# Altium schematic sheet Controller.SchDoc(Controller)
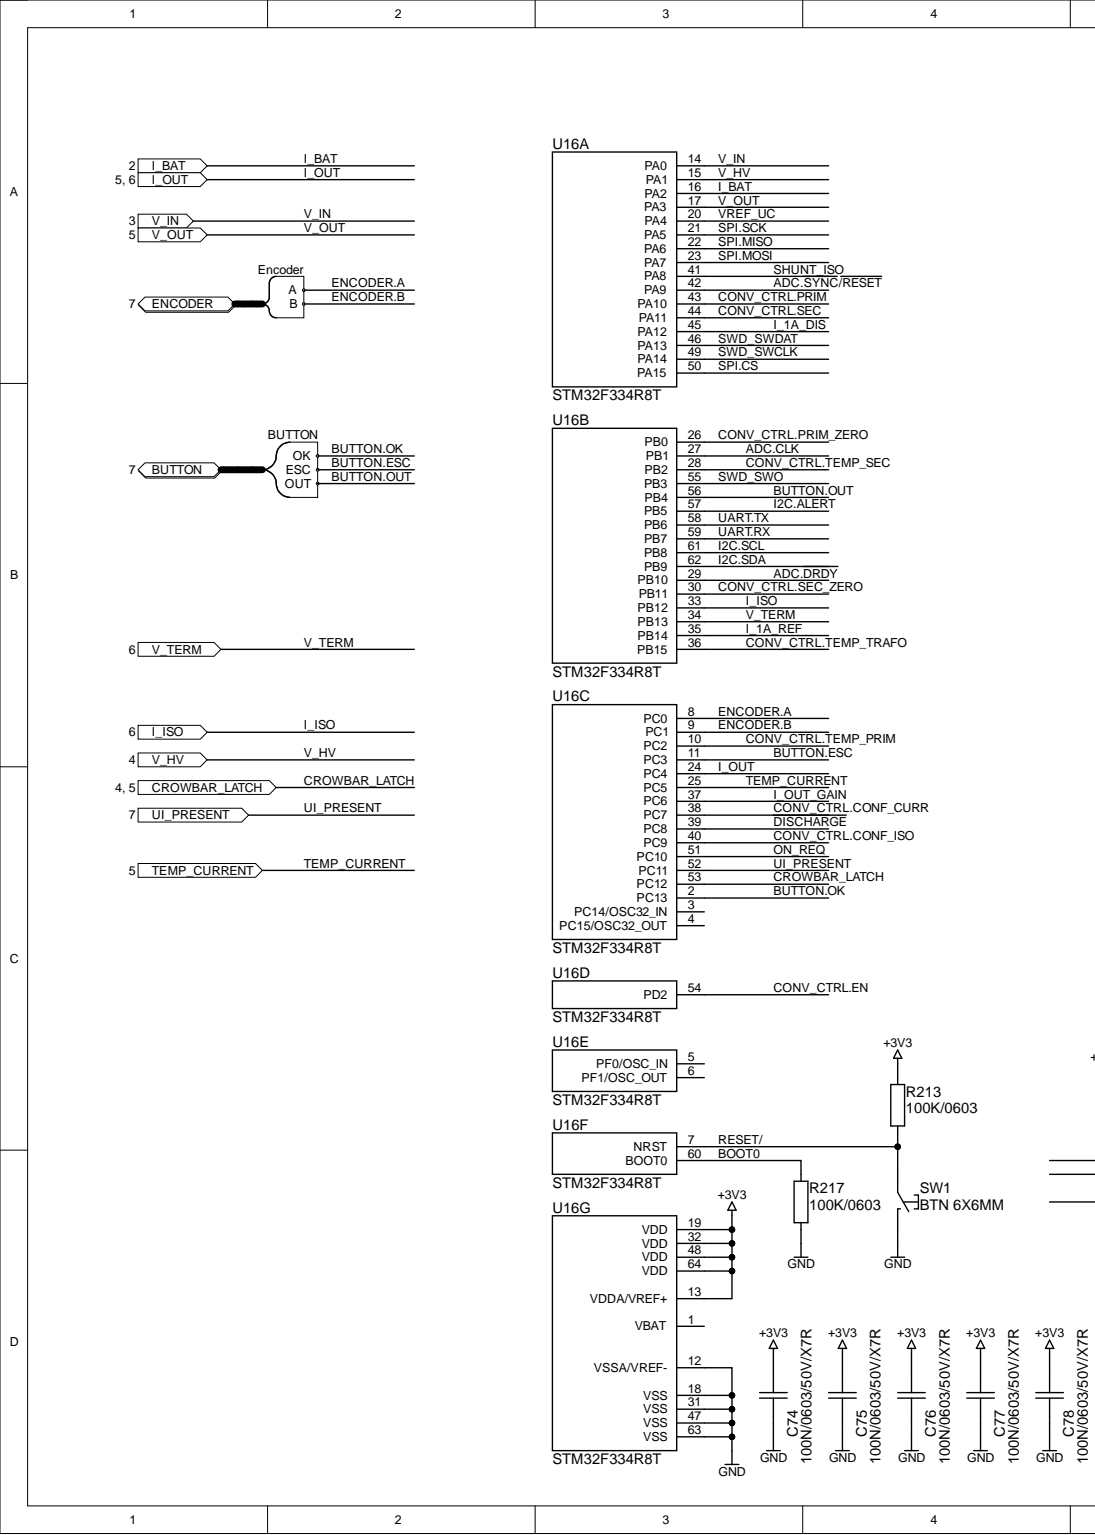
[diagram p0: Controller.SchDoc, left]
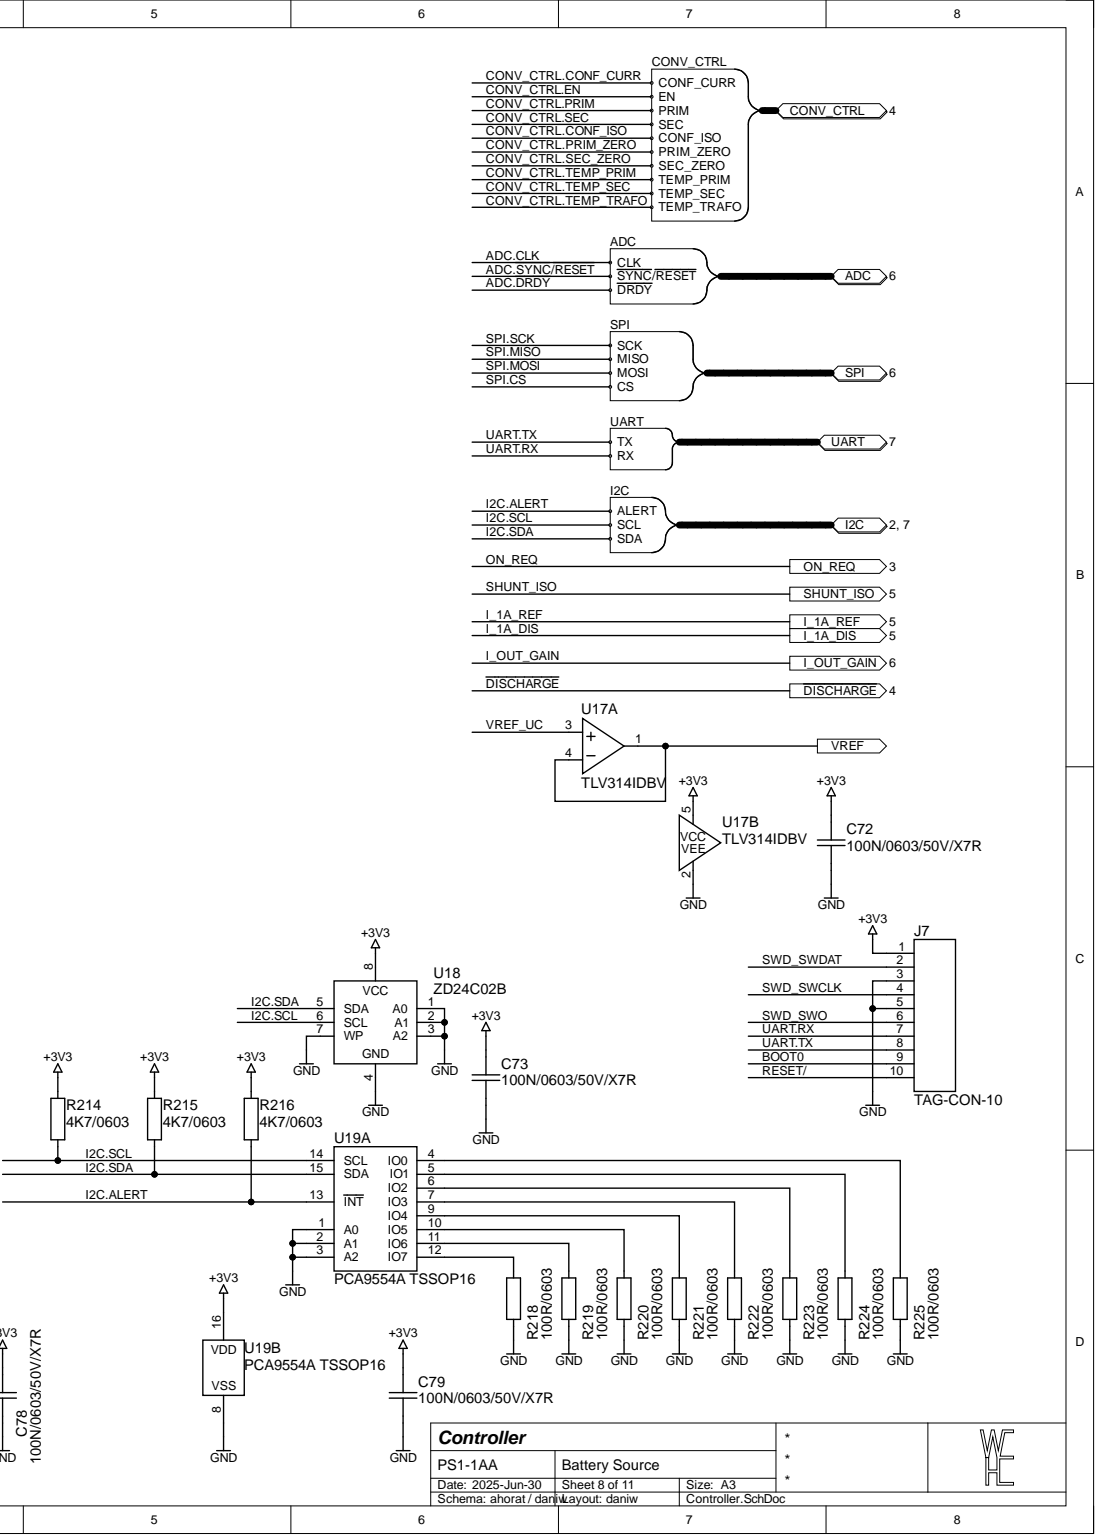
[diagram p1: Controller.SchDoc, right]

## Parts
C72  100N/0603/50V/X7R  [CAP0603_X7R]
C73  100N/0603/50V/X7R  [CAP0603_X7R]
C74  100N/0603/50V/X7R  [CAP0603_X7R]
C75  100N/0603/50V/X7R  [CAP0603_X7R]
C76  100N/0603/50V/X7R  [CAP0603_X7R]
C77  100N/0603/50V/X7R  [CAP0603_X7R]
C78  100N/0603/50V/X7R  [CAP0603_X7R]
C79  100N/0603/50V/X7R  [CAP0603_X7R]
J7  TAG-CON-10  [TC2050]
R213  100K/0603  [R0603-0.40-WW]
R214  4K7/0603  [R0603-0.40-WW]
R215  4K7/0603  [R0603-0.40-WW]
R216  4K7/0603  [R0603-0.40-WW]
R217  100K/0603  [R0603-0.40-WW]
R218  100R/0603  [R0603-0.40-WW]
R219  100R/0603  [R0603-0.40-WW]
R220  100R/0603  [R0603-0.40-WW]
R221  100R/0603  [R0603-0.40-WW]
R222  100R/0603  [R0603-0.40-WW]
R223  100R/0603  [R0603-0.40-WW]
R224  100R/0603  [R0603-0.40-WW]
R225  100R/0603  [R0603-0.40-WW]
SW1  BTN 6X6MM  [BTN-6X6MM-4.3MM-YELLOW]
U16  STM32F334R8T  [QFP050P1200X1200X160-64]  (parts A,B,C,D,E,F,G)
U17  TLV314IDBV  [SOT2395P280X125-5-NW]  (parts A,B)
U18  ZD24C02B  [SOP127P600X155-8-SW]
U19  PCA9554A TSSOP16  [SOP065P640X110-16NW]  (parts A,B)

## Ports
ADC, ADC.CLK, ADC.~{DRDY}, ADC.~{SYNC}/~{RESET}, BUTTON, BUTTON.ESC, BUTTON.OK, BUTTON.OUT, CONV_CTRL, CONV_CTRL.CONF_CURR, CONV_CTRL.CONF_ISO, CONV_CTRL.EN, CONV_CTRL.PRIM, CONV_CTRL.PRIM_ZERO, CONV_CTRL.SEC, CONV_CTRL.SEC_ZERO, CONV_CTRL.TEMP_PRIM, CONV_CTRL.TEMP_SEC, CONV_CTRL.TEMP_TRAFO, CROWBAR_LATCH, ENCODER, ENCODER.A, ENCODER.B, I2C, I2C.ALERT, I2C.SCL, I2C.SDA, I_1A_DIS, I_1A_REF, I_BAT, I_ISO, I_OUT, I_OUT_GAIN, ON_REQ, SHUNT_ISO, SPI, SPI.CS, SPI.MISO, SPI.MOSI, SPI.SCK, TEMP_CURRENT, UART, UART.RX, UART.TX, UI_PRESENT, V_HV, V_IN, V_OUT, V_TERM, VREF, ~{DISCHARGE}

## Nets
+3V3: C72.1 C73.1 C74.1 C75.1 C76.1 C77.1 C78.1 C79.1 J7.1 R213.1 R214.1 R215.1 R216.1 U16.13(VDDA/VREF+) U16.19(VDD) U16.32(VDD) U16.48(VDD) U16.64(VDD) U17.5 U18.8 U19.16
GND: C72.2 C73.2 C74.2 C75.2 C76.2 C77.2 C78.2 C79.2 J7.3 J7.5 R217.2 R218.2 R219.2 R220.2 R221.2 R222.2 R223.2 R224.2 R225.2 SW1.2 U16.12(VSSA/VREF-) U16.18(VSS) U16.31(VSS) U16.47(VSS) U16.63(VSS) U17.2 U18.1(A0) U18.2(A1) U18.3(A2) U18.4 U18.7(WP) U19.1(A0) U19.2(A1) U19.3(A2) U19.8
ADC.CLK: U16.27(PB1) ; port ADC
ADC.~{DRDY}: U16.29(PB10) ; port ADC
ADC.~{SYNC}/~{RESET}: U16.42(PA9) ; port ADC
BOOT0: J7.9 R217.1 U16.60(BOOT0)
BUTTON.ESC: U16.11(PC3) ; port BUTTON
BUTTON.OK: U16.2(PC13) ; port BUTTON
BUTTON.OUT: U16.56(PB4) ; port BUTTON
CONV_CTRL.CONF_CURR: U16.38(PC7) ; port CONV_CTRL
CONV_CTRL.CONF_ISO: U16.40(PC9) ; port CONV_CTRL
CONV_CTRL.EN: U16.54(PD2) ; port CONV_CTRL
CONV_CTRL.PRIM: U16.43(PA10) ; port CONV_CTRL
CONV_CTRL.PRIM_ZERO: U16.26(PB0) ; port CONV_CTRL
CONV_CTRL.SEC: U16.44(PA11) ; port CONV_CTRL
CONV_CTRL.SEC_ZERO: U16.30(PB11) ; port CONV_CTRL
CONV_CTRL.TEMP_PRIM: U16.10(PC2) ; port CONV_CTRL
CONV_CTRL.TEMP_SEC: U16.28(PB2) ; port CONV_CTRL
CONV_CTRL.TEMP_TRAFO: U16.36(PB15) ; port CONV_CTRL
CROWBAR_LATCH: U16.53(PC12) ; port CROWBAR_LATCH
~{DISCHARGE}: U16.39(PC8) ; port ~{DISCHARGE}
ENCODER.A: U16.8(PC0) ; port ENCODER
ENCODER.B: U16.9(PC1) ; port ENCODER
I2C.ALERT: R216.2 U16.57(PB5) U19.13(INT) ; port I2C
I2C.SCL: R214.2 U16.61(PB8) U18.6(SCL) U19.14(SCL) ; port I2C
I2C.SDA: R215.2 U16.62(PB9) U18.5(SDA) U19.15(SDA) ; port I2C
I_1A_DIS: U16.45(PA12) ; port I_1A_DIS
I_1A_REF: U16.35(PB14) ; port I_1A_REF
I_BAT: U16.16(PA2) ; port I_BAT
I_ISO: U16.33(PB12) ; port I_ISO
I_OUT: U16.24(PC4) ; port I_OUT
I_OUT_GAIN: U16.37(PC6) ; port I_OUT_GAIN
ON_REQ: U16.51(PC10) ; port ON_REQ
RESET/: J7.10 R213.2 SW1.1 U16.7(NRST)
SHUNT_ISO: U16.41(PA8) ; port SHUNT_ISO
SPI.CS: U16.50(PA15) ; port SPI
SPI.MISO: U16.22(PA6) ; port SPI
SPI.MOSI: U16.23(PA7) ; port SPI
SPI.SCK: U16.21(PA5) ; port SPI
SWD_SWCLK: J7.4 U16.49(PA14)
SWD_SWDAT: J7.2 U16.46(PA13)
SWD_SWO: J7.6 U16.55(PB3)
TEMP_CURRENT: U16.25(PC5) ; port TEMP_CURRENT
UART.RX: J7.7 U16.59(PB7) ; port UART
UART.TX: J7.8 U16.58(PB6) ; port UART
UI_PRESENT: U16.52(PC11) ; port UI_PRESENT
V_HV: U16.15(PA1) ; port V_HV
V_IN: U16.14(PA0) ; port V_IN
V_OUT: U16.17(PA3) ; port V_OUT
V_TERM: U16.34(PB13) ; port V_TERM
VREF: U17.1 U17.4 ; port VREF
VREF_UC: U16.20(PA4) U17.3
NetR218_1: R218.1 U19.12(IO7)
NetR219_1: R219.1 U19.11(IO6)
NetR220_1: R220.1 U19.10(IO5)
NetR221_1: R221.1 U19.9(IO4)
NetR222_1: R222.1 U19.7(IO3)
NetR223_1: R223.1 U19.6(IO2)
NetR224_1: R224.1 U19.5(IO1)
NetR225_1: R225.1 U19.4(IO0)
NetU16_1: U16.1(VBAT)
NetU16_3: U16.3(PC14/OSC32_IN)
NetU16_4: U16.4(PC15/OSC32_OUT)
NetU16_5: U16.5(PF0/OSC_IN)
NetU16_6: U16.6(PF1/OSC_OUT)

## Library data of the parts
C72-C79 = 100N/0603/50V/X7R: CAP SM 0603 100nF 50V X7R; Manufacturer: GENERIC; Manufacturer 1: YAGEO; Manufacturer Part Number 1: CC0603KPX7R9BB104; Absolute Value: 1E-7; Database Table Name: C$; Eurocircuits GPN: GPC0603104; Component Kind: Standard; HelpURL: https://www.yageo.com/upload/media/product/app/datasheet/mlcc/upy-gphc_x7r_6_3v-to-250v.pdf; JLC Type: Basic; Manufacturer 2: YAGEO; Manufacturer Part Number 2: CC0603MRX7R9BB104; Manufacturer Part Number 3: CC0603KRX7R9BB104; Package: 0603; Part Number: 100N/0603/50V/X7R; Supplier 1: Digi-Key; Supplier 2: Digi-Key; Supplier 3: LCSC; Supplier Part Number 1: 311-3567-1-ND; Supplier Part Number 2: 311-4110-1-ND; Supplier Part Number 3: C14663; Value: 100nF; Library Name: daniw_altium_lib.DbLib
J7 = TAG-CON-10: Tag-Connect 2050, 10 pins; Database Table Name: Misc$; Component Kind: Standard; HelpURL: https://www.tag-connect.com/wp-content/uploads/bsk-pdf-manager/TC2050-IDC_Datasheet_7.pdf; Manufacturer: TAG-CONNECT; Part Number: TAG-CON-10; Library Name: daniw_altium_lib.DbLib
R213 R217 = 100K/0603: RES SM 0603 100K; Manufacturer: GENERIC; Manufacturer 1: YAGEO; Manufacturer Part Number 1: RC0603FR-13100KL; Absolute Value: 100000; Database Table Name: R$; Eurocircuits GPN: GPR0603100K; Component Kind: Standard; HelpURL: https://www.yageo.com/upload/media/product/productsearch/datasheet/rchip/PYu-RC_Group_51_RoHS_L_12.pdf; JLC Type: Basic; Manufacturer 2: YAGEO; Manufacturer 3: UNI-ROYAL(Uniroyal Elec); Manufacturer Part Number 2: RC0603FR-10100KL; Manufacturer Part Number 3: 0603WAF1003T5E; Package: 0603; Part Number: 100K/0603; Supplier 1: Digi-Key; Supplier 2: Digi-Key; Supplier 3: LCSC; Supplier Part Number 1: 13-RC0603FR-13100KLCT-ND; Supplier Part Number 2: 13-RC0603FR-10100KLCT-ND; Supplier Part Number 3: C25803; Value: 100K; Library Name: daniw_altium_lib.DbLib
R214-R216 = 4K7/0603: RES SM 0603 4K7; Manufacturer: GENERIC; Manufacturer 1: YAGEO; Manufacturer Part Number 1: RC0603FR-134K7L; Absolute Value: 4700; Database Table Name: R$; Eurocircuits GPN: GPR06034K7; Component Kind: Standard; HelpURL: https://www.yageo.com/upload/media/product/app/datasheet/rchip/pyu-rc_group_51_rohs_l.pdf; JLC Type: Basic; Manufacturer 2: YAGEO; Manufacturer 3: UNI-ROYAL(Uniroyal Elec); Manufacturer Part Number 2: RC0603FR-104K7L; Manufacturer Part Number 3: 0603WAF4701T5E; Package: 0603; Part Number: 4K7/0603; Supplier 1: Digi-Key; Supplier 2: Digi-Key; Supplier 3: LCSC; Supplier Part Number 1: 13-RC0603FR-134K7LCT-ND; Supplier Part Number 2: 13-RC0603FR-104K7LCT-ND; Supplier Part Number 3: C23162; Value: 4K7; Library Name: daniw_altium_lib.DbLib
R218-R225 = 100R/0603: RES SM 0603 100R; Manufacturer: GENERIC; Manufacturer 1: YAGEO; Manufacturer Part Number 1: RC0603FR-13100RL; Absolute Value: 100; Database Table Name: R$; Eurocircuits GPN: GPR0603100R; Component Kind: Standard; HelpURL: https://www.yageo.com/upload/media/product/productsearch/datasheet/rchip/PYu-RC_Group_51_RoHS_L_12.pdf; Manufacturer 2: YAGEO; Manufacturer 3: UNI-ROYAL(Uniroyal Elec); Manufacturer Part Number 2: RC0603FR-10100RL; Manufacturer Part Number 3: 0603WAF1000T5E; Package: 0603; Part Number: 100R/0603; Supplier 1: Digi-Key; Supplier 2: Digi-Key; Supplier 3: LCSC; Supplier Part Number 1: 13-RC0603FR-13100RLCT-ND; Supplier Part Number 2: 13-RC0603FR-10100RLCT-ND; Supplier Part Number 3: C22775; Value: 100R; Library Name: daniw_altium_lib.DbLib
SW1 = BTN 6X6MM 4.3MM 1.5N: Pushbutton switch, PCB mount, 6x6mm, 4.3mm height, 1.5N operating force; Manufacturer 1: Omron Electronics Inc-EMC Div; Manufacturer Part Number 1: B3F-1002; Database Table Name: Misc$; Component Kind: Standard; HelpURL: https://omronfs.omron.com/en_US/ecb/products/pdf/en-b3f.pdf; Part Number: BTN 6X6MM 4.3MM 1.5N; Supplier 1: Digi-Key; Supplier Part Number 1: SW401-ND; Library Name: daniw_altium_lib.DbLib
U16 = STM32F334R8T: STM32F334R8T, Arm ® Cortex ® -M4 32b MCU+FPU,64KB Flash,16KB SRAM, 2 ADCs,3 DACs,3 comp.,op-amp, 217ps 10-ch (HRTIM1); Manufacturer: ST Microelectronics; Manufacturer 1: Texas Instruments; Manufacturer Part Number 1: STM32F334R8T6TR; Database Table Name: Integrated$; Component Kind: Standard; HelpURL: https://www.st.com/resource/en/datasheet/stm32f334r8.pdf; Manufacturer 2: STMicroelectronics; Manufacturer 3: STMicroelectronics; Manufacturer Part Number 2: STM32F334R8T6; Manufacturer Part Number 3: STM32F334R8T6; Package: QFP-64; Part Number: STM32F334R8T; Supplier 1: Digi-Key; Supplier 2: Digi-Key; Supplier 3: LCSC; Supplier Part Number 1: 497-STM32F334R8T6TRCT-ND; Supplier Part Number 2: 497-14955-ND; Supplier Part Number 3: C80577; Library Name: daniw_altium_lib.DbLib
U17 = TLV314 SOT23: TLV314, 3-MHz, Low-Power, Internal EMI Filter, RRIO, Operational Amplifier; Manufacturer: Texas Instruments; Manufacturer Part Number 1: TLV314IDBVR; Database Table Name: Integrated$; Component Kind: Standard; HelpURL: https://www.ti.com/lit/ds/symlink/tlv4314.pdf; Manufacturer 1: Texas Instruments; Manufacturer 2: Texas Instruments; Manufacturer 3: Texas Instruments; Manufacturer Part Number 2: TLV314IDBVT; Manufacturer Part Number 3: TLV314IDBVR; Package: SOT23-5; Part Number: TLV314 SOT23; Supplier 1: Digi-Key; Supplier 2: Digi-Key; Supplier 3: LCSC; Supplier Part Number 1: 296-46275-1-ND; Supplier Part Number 2: 296-44110-2-ND; Supplier Part Number 3: C133032; Library Name: daniw_altium_lib.DbLib
U18 = ZD24C02B: I2C-Compatible (2-wire) Serial EEPROM 2K bits; Manufacturer: Zetta; Database Table Name: Integrated$; Component Kind: Standard; HelpURL: https://datasheet.lcsc.com/lcsc/2402020955_Zetta-ZD24C02B-SSGMB_C5374786.pdf; JLC Type: Extended; Manufacturer 3: Zetta; Manufacturer Part Number 3: ZD24C02B-SSGMB; Package: SOIC-8; Part Number: ZD24C02B; Supplier 3: LCSC; Supplier Part Number 3: C5374786; Library Name: daniw_altium_lib.DbLib
U19 = PCA9554A TSSOP16: PCA9554A 8-bit I2C-bus and SMBus I/O port with interrupt; Manufacturer: NXP / Texas Instruments; Manufacturer 1: NXP USA Inc.; Manufacturer Part Number 1: PCA9554APW,118; Database Table Name: Integrated$; Component Kind: Standard; HelpURL: https://www.nxp.com/docs/en/data-sheet/PCA9554_9554A.pdf; Manufacturer 3: NXP Semicon; Manufacturer Part Number 3: PCA9554APW,118; Package: TSSOP-16; Part Number: PCA9554A TSSOP16; Supplier 1: Digi-Key; Supplier 3: LCSC; Supplier Part Number 1: 568-11905-1-ND; Supplier Part Number 3: C86803; Library Name: daniw_altium_lib.DbLib
